# Altium schematic sheet 5V_3V_LDO.SchDoc
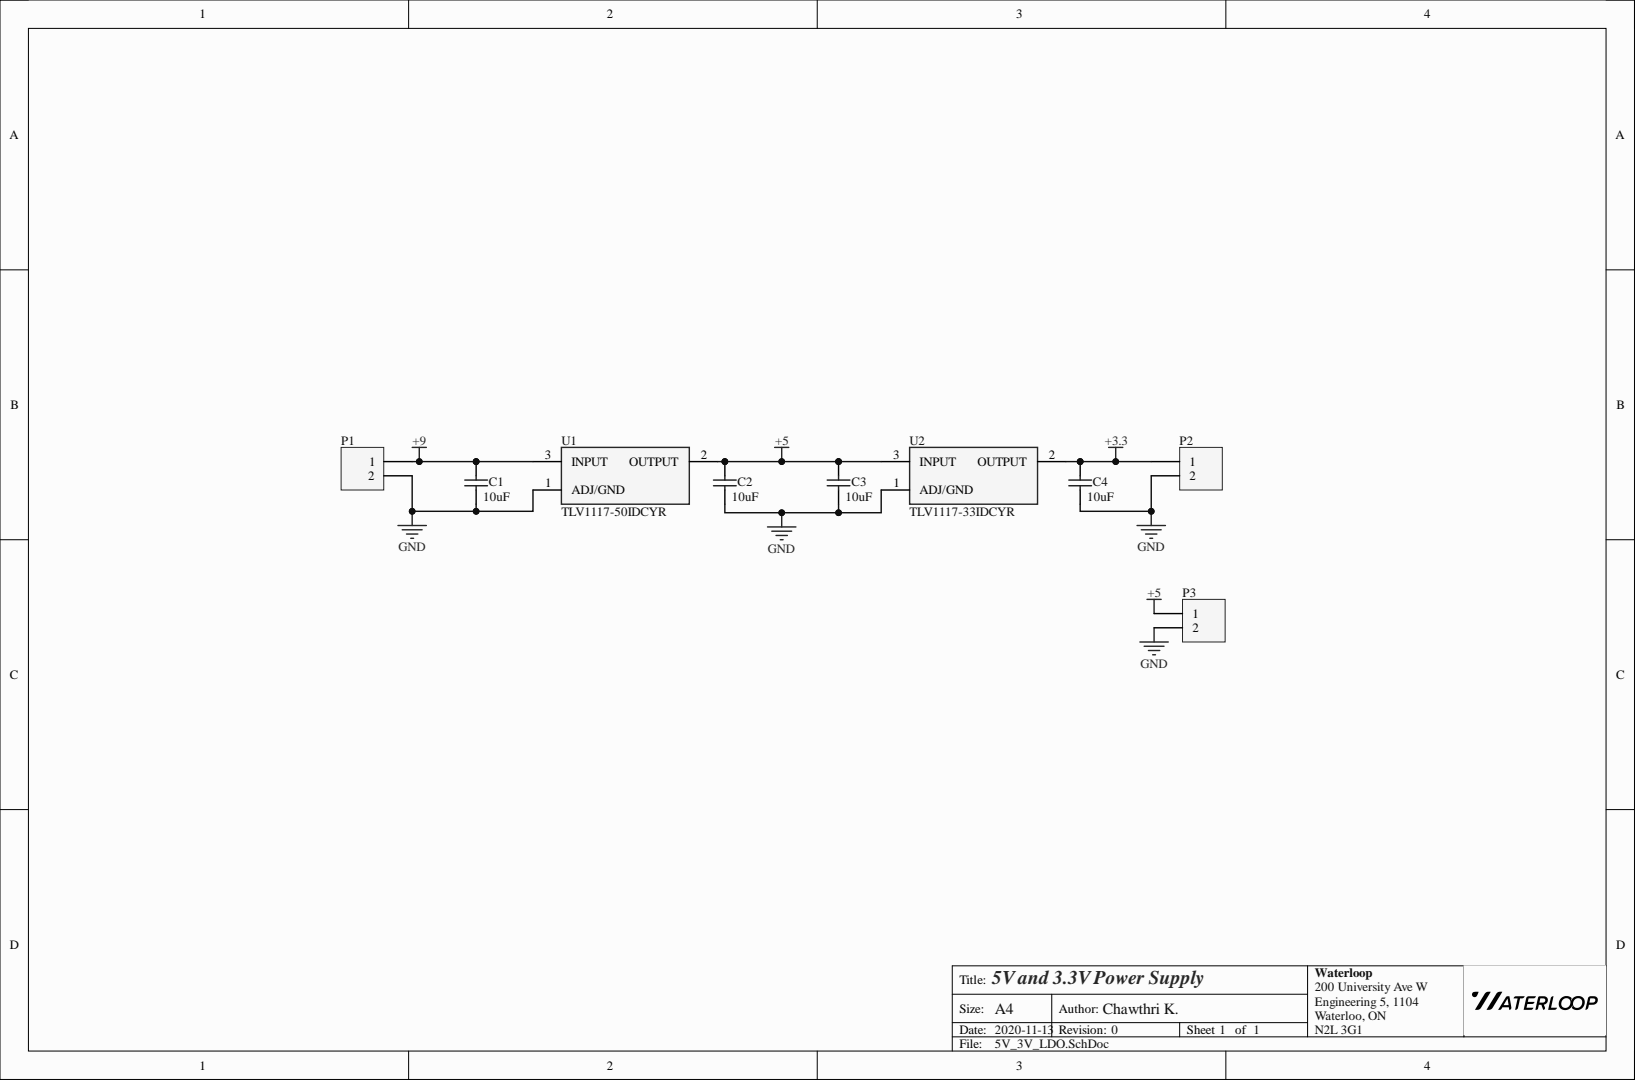
[diagram p0: 5V_3V_LDO.SchDoc]

## Parts
C1  value 10uF  [0805 - Capacitor]  (hidden comment: Capacitor - Nonpolarized)
C2  value 10uF  [0805 - Capacitor]  (hidden comment: Capacitor - Nonpolarized)
C3  value 10uF  [0805 - Capacitor]  (hidden comment: Capacitor - Nonpolarized)
C4  value 10uF  [0805 - Capacitor]  (hidden comment: Capacitor - Nonpolarized)
P1  1x2 Header  [HDR1X2]  (value not drawn)
P2  1x2 Header  [HDR1X2]  (value not drawn)
P3  1x2 Header  [HDR1X2]  (value not drawn)
U1  TLV1117-50IDCYR  [TLV1117]
U2  TLV1117-33IDCYR  [TLV1117]

## Nets
+3.3: C4.2 P2.1 U2.2
+5: C2.2 C3.2 P3.1 U1.2 U2.3
+9: C1.2 P1.1 U1.3
GND: C1.1 C2.1 C3.1 C4.1 P1.2 P2.2 P3.2 U1.1 U2.1

## Library data of the parts
C1-C4 = Capacitor - Nonpolarized: Nonpolarized Capacitor; Manufacturer: Yageo; Manufacturer Part Number: CC0805KKX5R8BB106; Component Kind: Standard; Library Name: General.SchLib; Signal Integrity: Cap; Simulation: CAP; Supplier Part Number: 311-1869-1-ND
P1-P3 = 1x2 Header: 1x2 Pin Header; Component Kind: Standard; Library Name: GeneralConnectors.IntLib; Signal Integrity: Connector
U1 = TLV1117-50IDCYR: Linear Voltage Regulator IC 5V 800MA SOT223-4; Manufacturer: Texas Instruments; Component Kind: Standard; Datasheet Link: http://www.ti.com/lit/ds/symlink/tlv1117.pdf?HQS=TI-null-null-digikeymode-df-pf-null-wwe&ts=1590668900314; Digikey Part Number: 296-21115-1-ND; Library Name: GeneralComponents.IntLib; Manufacturer Part Number: TLV1117-50IDCYR; Mouser Part Number: 595-TLV1117-50IDCYR
U2 = TLV1117-33IDCYR: Linear Voltage Regulator IC 3.3V 800MA SOT223-4; Manufacturer: Texas Instruments; Component Kind: Standard; Datasheet Link: http://www.ti.com/lit/ds/symlink/tlv1117.pdf?HQS=TI-null-null-digikeymode-df-pf-null-wwe&ts=1590668900314; Digikey Part Number: 296-21112-1-ND; Library Name: GeneralComponents.IntLib; Manufacturer Part Number: TLV1117-33IDCYR; Mouser Part Number: 595-TLV1117-33IDCYR
